# Altium schematic sheet PowerSupply.SchDoc(PowerSupply)
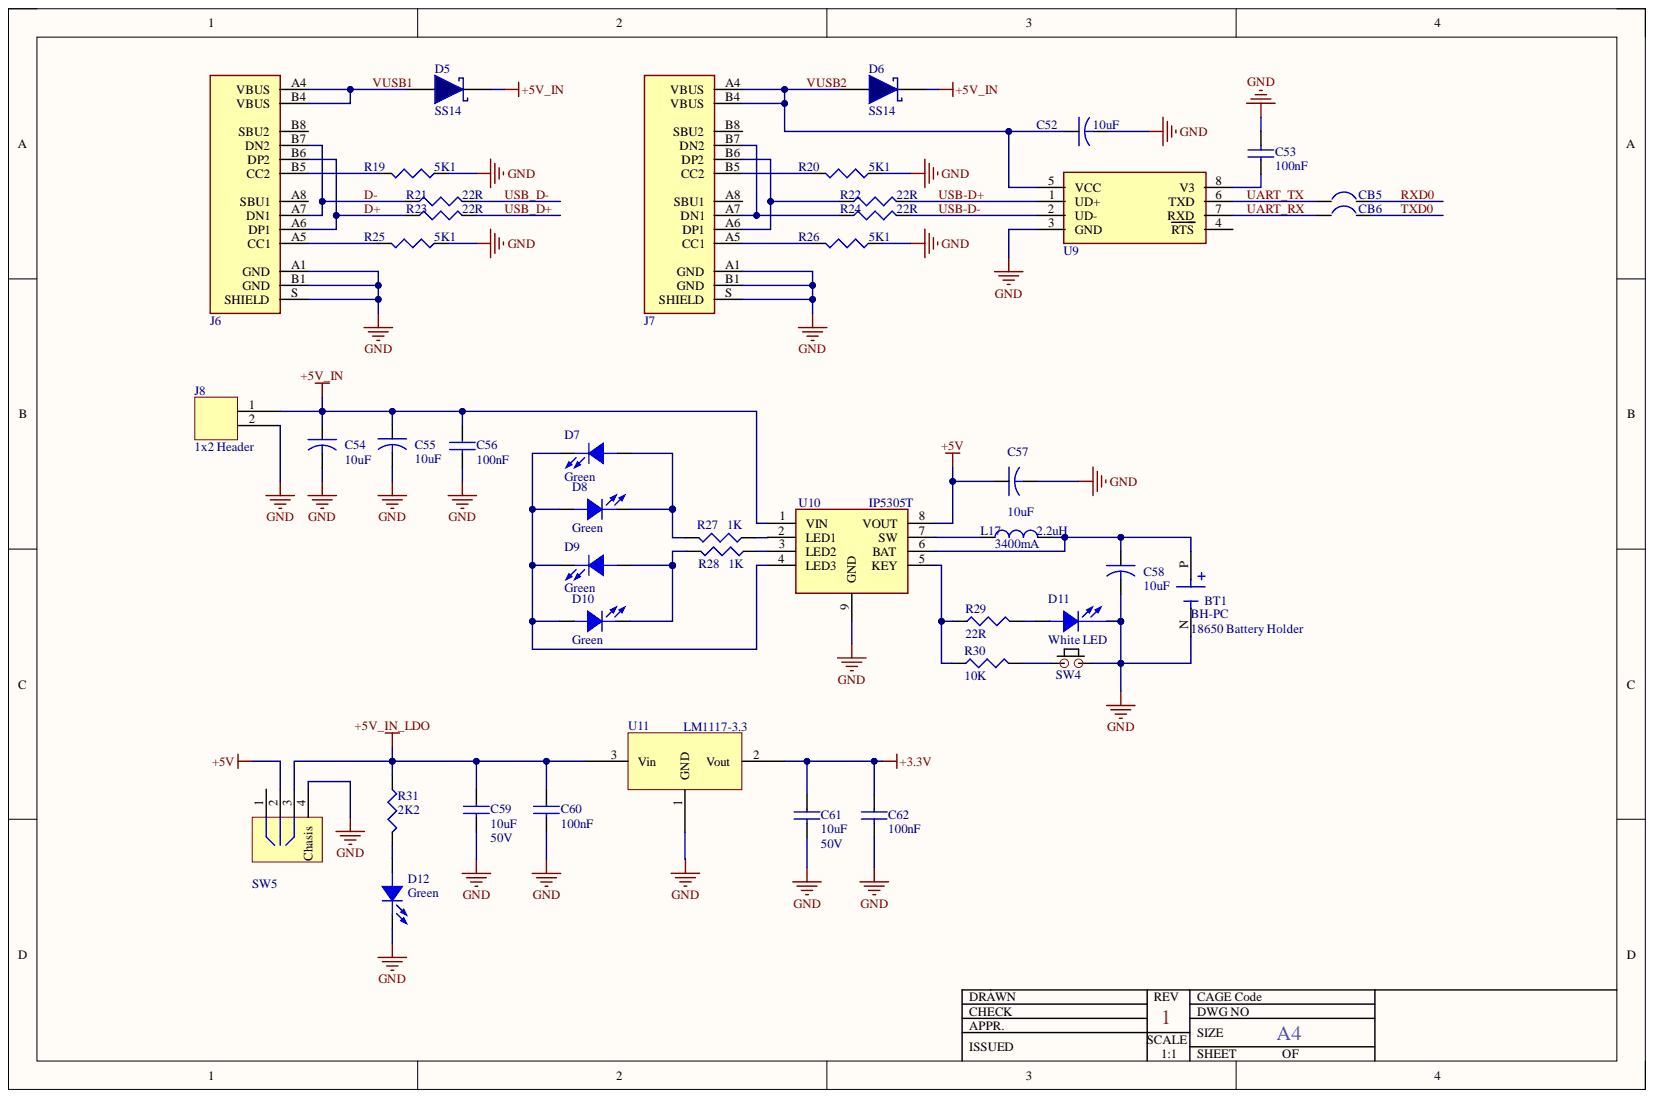
[diagram p0: PowerSupply.SchDoc]

## Parts
BT1  BH-PC  value 18650 Battery Holder  [BH-PC-DIP]
C52  value 10uF  [A Case]  (hidden comment: T491A106)
C53  value 100nF  [0805 SMD Ceramic Capacitor]  (hidden comment: C0805C104)
C54  value 10uF  [A Case]  (hidden comment: T491A106)
C55  value 10uF  [A Case]  (hidden comment: T491A106)
C56  value 100nF  [0805 SMD Ceramic Capacitor]  (hidden comment: C0805C104)
C57  value 10uF  [A Case]  (hidden comment: T491A106)
C58  value 10uF  [A Case]  (hidden comment: T491A106)
C59  value 10uF  Voltage: 50V  [1206 SMD Ceramic Capacitor]  (hidden comment: C1206C106)
C60  value 100nF  [0805 SMD Ceramic Capacitor]  (hidden comment: C0805C104)
C61  value 10uF  Voltage: 50V  [1206 SMD Ceramic Capacitor]  (hidden comment: C1206C106)
C62  value 100nF  [0805 SMD Ceramic Capacitor]  (hidden comment: C0805C104)
CB5  CircuitBraker  [CircuitBraker]  (value not drawn)
CB6  CircuitBraker  [CircuitBraker]  (value not drawn)
D5  SS14  [SMA]
D6  SS14  [SMA]
D7  value Green  [0805 Green LED]  (hidden comment: 0805 Green LED)
D8  value Green  [0805 Green LED]  (hidden comment: 0805 Green LED)
D9  value Green  [0805 Green LED]  (hidden comment: 0805 Green LED)
D10  value Green  [0805 Green LED]  (hidden comment: 0805 Green LED)
D11  value White LED  [SMD3528]  (hidden comment: WhiteLED-SMD)
D12  value Green  [0805 Green LED]  (hidden comment: 0805 Green LED)
J6  TYPE-C-31-M-12  [HRO_TYPE-C-31-M-12]  (value not drawn)
J7  TYPE-C-31-M-12  [HRO_TYPE-C-31-M-12]  (value not drawn)
J8  value 1x2 Header  [1x2 2 Pin Header]  (hidden comment: 1x2 2 Pin Header)
L17  2.2uH_3400mA  value 2.2uH  Current: 3400mA  [SMNR4020]
R19  value 5K1  [0805 Resistor]  (hidden comment: 0805_5K1%1)
R20  value 5K1  [0805 Resistor]  (hidden comment: 0805_5K1%1)
R21  value 22R  [0805 Resistor]  (hidden comment: 0805_22R%1)
R22  value 22R  [0805 Resistor]  (hidden comment: 0805_22R%1)
R23  value 22R  [0805 Resistor]  (hidden comment: 0805_22R%1)
R24  value 22R  [0805 Resistor]  (hidden comment: 0805_22R%1)
R25  value 5K1  [0805 Resistor]  (hidden comment: 0805_5K1%1)
R26  value 5K1  [0805 Resistor]  (hidden comment: 0805_5K1%1)
R27  value 1K  [0805 Resistor]  (hidden comment: 0805_1K)
R28  value 1K  [0805 Resistor]  (hidden comment: 0805_1K)
R29  value 22R  [0805 Resistor]  (hidden comment: 0805_22R%1)
R30  value 10K  [0805 Resistor]  (hidden comment: 0805_10K)
R31  value 2K2  [0805 Resistor]  (hidden comment: 0805_2K2%1)
SW4  2.5mm Tactile Push Button  [2.5mm Tactile Push Button]  (value not drawn)
SW5  MSK12C02G15-B  [MSK12C02G15-B]  (value not drawn)
U9  CH340N  [SOIC127P600X160-8N]  (value not drawn)
U10  IP5305T  [DDA8]
U11  LM1117-3.3  [SOT223]

## Nets
+3.3V: C61.1 C62.1 U11.2
+5V: C57.1 SW5.2 U10.8(VOUT)
+5V_IN: C54.1 C55.1 C56.1 D5.2 D6.2 J8.1 U10.1(VIN)
+5V_IN_LDO: C59.1 C60.1 R31.1 SW5.3 U11.3
GND: BT1.N C52.2 C53.2 C54.2 C55.2 C56.2 C57.2 C58.2 C59.2 C60.2 C61.2 C62.2 D11.2 D12.2 J6.A1(GND) J6.B1(GND) J6.S(SHIELD) J7.A1(GND) J7.B1(GND) J7.S(SHIELD) J8.2 R19.1 R20.1 R25.1 R26.1 SW4.2 SW5.4(Chasis) U9.3(GND) U10.9(GND) U11.1
D+: J6.A6(DP1) J6.B6(DP2) R23.1
D-: J6.A7(DN1) J6.B7(DN2) R21.1
RXD0: CB5.2
TXD0: CB6.2
UART_RX: CB6.1 U9.7(RXD)
UART_TX: CB5.1 U9.6(TXD)
USB-D+: R22.2 U9.1(UD+)
USB-D-: R24.2 U9.2(UD-)
USB_D+: R23.2
USB_D-: R21.2
VUSB1: D5.1 J6.A4(VBUS) J6.B4(VBUS)
VUSB2: C52.1 D6.1 J7.A4(VBUS) J7.B4(VBUS) U9.5(VCC)
NetBT1_P: BT1.P C58.1 L17.2 U10.6(BAT)
NetC53_1: C53.1 U9.8(V3)
NetD7_1: D7.1 D8.2 R27.2
NetD7_2: D7.2 D8.1 D9.2 D10.1 U10.4(LED3)
NetD9_1: D9.1 D10.2 R28.2
NetD11_1: D11.1 R29.2
NetD12_1: D12.1 R31.2
NetJ6_A5: J6.A5(CC1) R25.2
NetJ6_B5: J6.B5(CC2) R19.2
NetJ7_A5: J7.A5(CC1) R26.2
NetJ7_A6: J7.A6(DP1) J7.B6(DP2) R22.1
NetJ7_A7: J7.A7(DN1) J7.B7(DN2) R24.1
NetJ7_B5: J7.B5(CC2) R20.2
NetL17_1: L17.1 U10.7(SW)
NetR27_1: R27.1 U10.2(LED1)
NetR28_1: R28.1 U10.3(LED2)
NetR29_1: R29.1 R30.1 U10.5(KEY)
NetR30_2: R30.2 SW4.1

Not connected: J6 A8(SBU1) B8(SBU2); J7 A8(SBU1) B8(SBU2); SW5 1; U9 4(RTS)

## Library data of the parts
BT1 = BH-PC: DIP Polarized Holder for 18650 Battery; Manufacturer: MPD; Manufacturer Code: BH-18650-PC; Component Kind: Standard; Library Name: 18650_BatterHolder.IntLib; Price: 0.5; Price Unit: $
C52 C54 C55 C57 C58 = T491A106: Manufacturer: KEMET; Manufacturer Code: T491A106K006AT; Voltage: 6V3; Component Kind: Standard; Farnell Code: 1457412; Library Name: Capacitors.SchLib; Unit Price: 0,143 €
C53 C56 C60 C62 = C0805C104: Manufacturer: KEMET; Manufacturer Code: C0805C104J5RACTU; Voltage: 50V; Component Kind: Standard; Farnell Code: 1414663; Library Name: Capacitors.SchLib; Package: 0805; Unit Price: 0,06 €
C59 C61 = C1206C106: Manufacturer: MULTICOMP; Manufacturer Code: MC1206B475K500CT; Capacitance Tolerance: ±10%; Component Kind: Standard; Farnell Code: 2320908; Library Name: Capacitors.SchLib; MOQ: 10; Unit Price: 0,644 €
D5 D6 = SS14: Manufacturer: onsemi; Forward Current: 1A; Voltage: 40V; Component Kind: Standard; Digikey Code: SS14TR-ND; Library Name: SS14.SchLib; Manufacturer Code: SS14; Unit Price: 0.29 $; Value: SS14
D7-D10 D12 = 0805 Green LED: Manufacturer: AVAGO Technologies; Manufacturer Code: HSMG-C170; Current: 20mA; Voltage: 2.2V; Component Kind: Standard; Farnell Code: 5790852; Library Name: LED.SchLib; Unit Price: 0,134 €
D11 = WhiteLED-SMD: Manufacturer: Everlight Elec; Manufacturer Code: 67-22ST/KKE-E30125380U2/SZM/2T; Component Kind: Standard; Library Name: LED.SchLib; Price Unit: $; Unit Price: 0.04
J6 J7 = TYPE-C-31-M-12: USB Type C Connector; Manufacturer: HRO Electronics Co., Ltd.; Component Kind: Standard; Library Name: TYPE-C-31-M-12.SchLib; Manufacturer Code: TYPE-C-31-M-12; Unit Price: 0.0438
L17 = 2.2uH_3400mA: Manufacturer: SXN(Shun Xiang Nuo Elec); Manufacturer Code: SMNR4020-2.2UH; Component Kind: Standard; Library Name: Inductors.SchLib; MOQ: 10; Unit Price: 0.0473 $
R19 R20 R25 R26 = 0805_5K1%1: 5.1 kOhms ±5% 0.125W, 1/8W Chip Resistor 0805 (2012 Metric) Moisture Resistant Thick Film; Manufacturer: YAGEO; Manufacturer Code: RC0805JR-075K1L; Component Kind: Standard; Digikey Code: 1469942; Library Name: Resistors.SchLib; Tolerance: ±5%; Unit Price: 0,01 $
R21-R24 R29 = 0805_22R%1: Manufacturer: MULTICOMP; Manufacturer Code: MC01W0805122R; Tolerance: ±1%; Component Kind: Standard; Farnell Code: 9332847; Library Name: Resistors.SchLib; MOQ: 10; Unit Price: 0,02 €
R27 R28 = 0805_1K: Manufacturer: MULTICOMP; Manufacturer Code: MC01W080511K; Tolerance: ±1%; Component Kind: Standard; Farnell Code: 9332383; Library Name: Resistors.SchLib; Unit Price: 0,03 €
R30 = 0805_10K: Manufacturer: MULTICOMP; Manufacturer Code: MC 0.1W 0805 1% 10K; Tolerance: ±1%; Component Kind: Standard; Farnell Code: 9332391; Library Name: Resistors.SchLib; Unit Price: 0,03 €
R31 = 0805_2K2%1: Manufacturer: MULTICOMP; Manufacturer Code: MC 0.1W 0805 1% 2K2; Temp. Coefficient: ±100ppm/°C; Tolerance: ±1%; Component Kind: Standard; Farnell Code: 9332812; Library Name: Resistors.SchLib; Unit Price: 0,033 €
SW5 = MSK12C02G15-B: SMD-7P,6.7x2.8mm Slide Switches ROHS; Manufacturer: HanElectricity; Value: Switch; Component Kind: Standard; Library Name: Switch_MSK12C02G15-B.SchLib; Manufacturer Code: MSK12C02G15-B; MOQ: 20; Unit Price: 0.0234 $
U9 = CH340N: USB to Serial Port Chip; Manufacturer: WCH; Component Kind: Standard; Library Name: CH340N.IntLib; Manufacturer Code: CH340N; Package: SO8; Price Unit: $; Unit Price: 0.67183; Value: CH340N
U10 = IP5305T: Fully-integrated power bank System-On-Chip with  1.2A charger, 1.0A boost converter; Manufacturer: INJOINIC; Component Kind: Standard; Library Name: IP5305T.SchLib; Manufacturer Code: IP5305T; Unit Price: 0.1849 $; Value: IP5305T
U11 = LM1117-3.3: Manufacturer: Texas Instruments; Manufacturer Code: LM1117MP-3.3; Current: 800mA; Voltage: 3.3V; Component Kind: Standard; Farnell Code: 9778195; Library Name: LM1117.SchLib; Unit Price: 1,14 €; Value: LM1117-3.3
CB5 CB6 = CircuitBraker: Component Kind: Standard; Description: CircuitBraker; Library Name: CircuitBraker.IntLib
J8 = 1x2 2 Pin Header: Component Kind: Standard; Library Name: Header.SchLib
SW4 = 2.5mm Tactile Push Button: Component Kind: Standard; Library Name: ButtonSwitch.SchLib; Value: 2.5mm Tactile Push Button
